# Altium schematic sheet SLA-3000-FPC_Page03.SchDoc
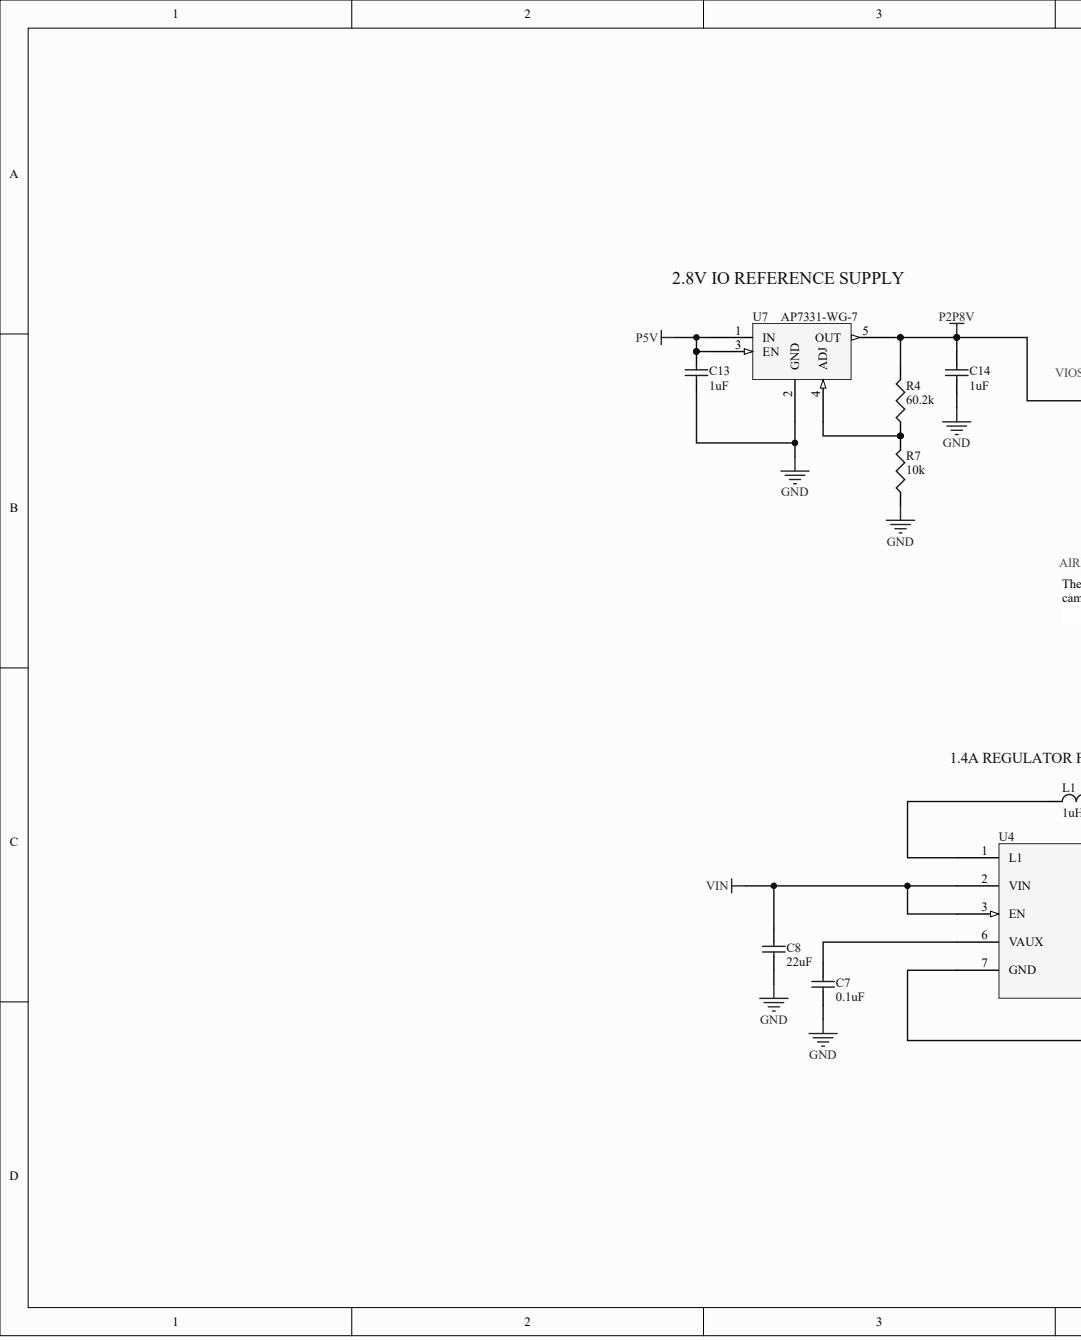
[diagram p0: SLA-3000-FPC_Page03.SchDoc, left]
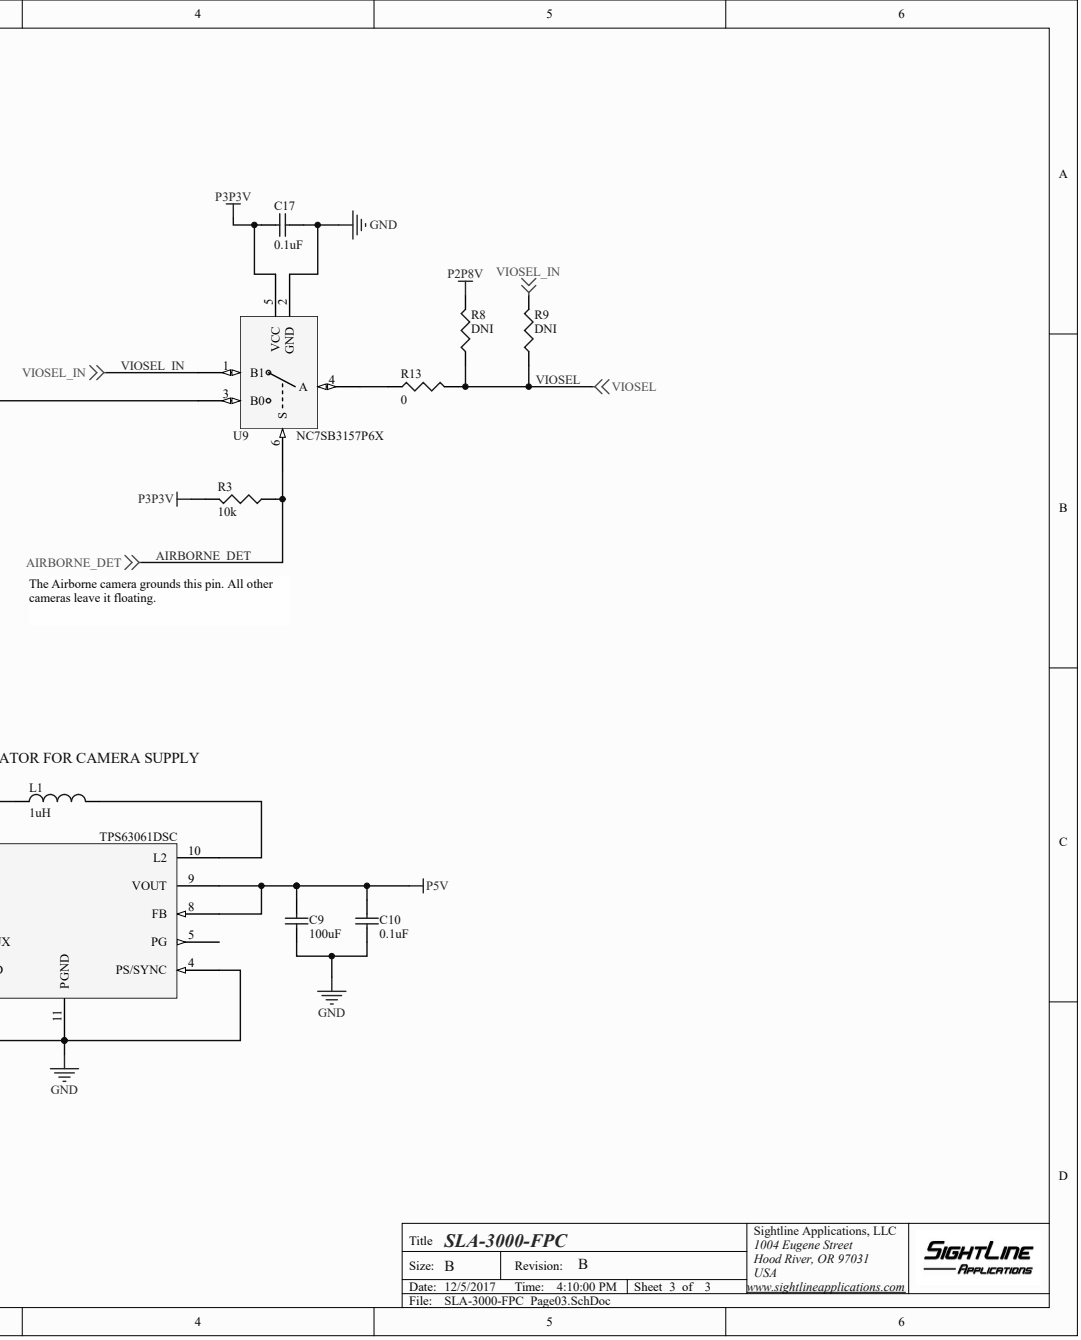
[diagram p1: SLA-3000-FPC_Page03.SchDoc, right]

## Parts
C7  0.1uF
C8  22uF
C9  100uF
C10  0.1uF
C13  1uF  [0402]
C14  1uF  [0402]
C17  0.1uF  [0402]
L1  1uH
R3  10k
R4  60.2k
R7  10k
R8  DNI
R9  DNI
R13  0  (value not drawn)
U4  TPS63061DSC
U7  AP7331-WG-7
U9  NC7SB3157P6X

## Nets
GND: C7.1 C8.1 C9.1 C10.1 C13.1 C14.1 C17.2 R7.1 U4.4(PS/SYNC) U4.7(GND) U4.11(PGND) U7.2(GND) U9.2(GND)
P2P8V: C14.2 R4.2 R8.2 U7.5(OUT) U9.3(B0)
P3P3V: C17.1 R3.2 U9.5(VCC)
P5V: C9.2 C10.2 C13.2 U4.8(FB) U4.9(VOUT) U7.1(IN) U7.3(EN)
VIN: C8.2 U4.2(VIN) U4.3(EN)
AIRBORNE_DET: R3.1 U9.6(S)
VIOSEL: R8.1 R9.1 R13.2
VIOSEL_IN: R9.2 U9.1(B1)
NetC7_2: C7.2 U4.6(VAUX)
NetL1_1: L1.1 U4.1(L1)
NetL1_2: L1.2 U4.10(L2)
NetR4_1: R4.1 R7.2 U7.4(ADJ)
NetR13_1: R13.1 U9.4(A)

Not connected: U4 5(PG)

## Library data of the parts
C7 C10 = (no symbol name): MfgPartNo: GRM155R71C104KA88D; SLA Part No: SL00316; Mfg: Murata; Type: Ceramic - X7R; Capacitance: 0.1uF; Package: 402
C8 = (no symbol name): MfgPartNo: EMK316BJ226ML-T; SLA Part No: SL00284; Mfg: Taiyo Yuden; Type: Ceramic - X5R; Capacitance: 22uF; Package: 1206
C9 = (no symbol name): MfgPartNo: C3216X5R1A107M160AC; SLA Part No: SL00073; Mfg: TDK; Type: Ceramic - X5R; Capacitance: 100uF; Package: 1206
C13 C14 = (no symbol name): MfgPartNo: GRM155R61A105KE15D; SLA Part No: SL00104; Rated Voltage: 10V; Tolerance: 10%; Type: Ceramic - X5R; Mfg: Murata; Value: 1uF; Package: 402; Capacitance: 1uF
C17 = (no symbol name): MfgPartNo: C1005X7R1E104K; SLA Part No: SL00150; Rated Voltage: 25V; Tolerance: 10%; Type: Ceramic - X7R; Mfg: TDK; Value: 0.1uF; Capacitance: 0.1uF; Package: 402
L1 = (no symbol name): MfgPartNo: BRC2012T1R0M; SLA Part No: SL00496; Mfg: Taiyo Yuden; Rated Current: 1.4A; HelpURL: http://www.yuden.co.jp/productdata/catalog/en/wound07_e.pdf; Inductance: 1uH; Package: 805
R3 R7 = (no symbol name): MfgPartNo: ERJ-2RKF1002X; SLA Part No: SL00225; Mfg: Panasonic; Package: 402; Resistance: 10k
R4 = (no symbol name): MfgPartNo: RC0402FR-0760K4L; SLA Part No: SL00742; Mfg: Yageo; Package: 0402; Resistance: 60.2k
R13 = (no symbol name): MfgPartNo: ERJ-2GE0R00X; SLA Part No: SL00128; Mfg: Panasonic; Package: 402; Resistance: 0
U4 = (no symbol name): SLA Part No: SL00499; Mfg: Texas Instruments; HelpURL: http://www.ti.com/lit/ds/symlink/tps63060.pdf; MfgPartNo: TPS63061DSC; Package: 10-SON (TI DSC)
U7 = (no symbol name): SLA Part No: SL00740; Mfg: Diodes Inc.; MfgPartNo: AP7331-WG-7; Package: SOT-25-3; HelpURL: http://www.diodes.com/_files/datasheets/AP7346.pdf
U9 = (no symbol name): SLA Part No: SL00723; Mfg: Fairchild; MfgPartNo: NC7SB3157P6X; Package: SC-70-6; HelpURL: https://www.fairchildsemi.com/datasheets/NC/NC7SB3157.pdf
R8 R9 = (no symbol name): Mfg: DNI; MfgPartNo: DNI; Package: 402; Resistance: DNI
